# Altium schematic sheet Interfaces.SchDoc(Interfaces)
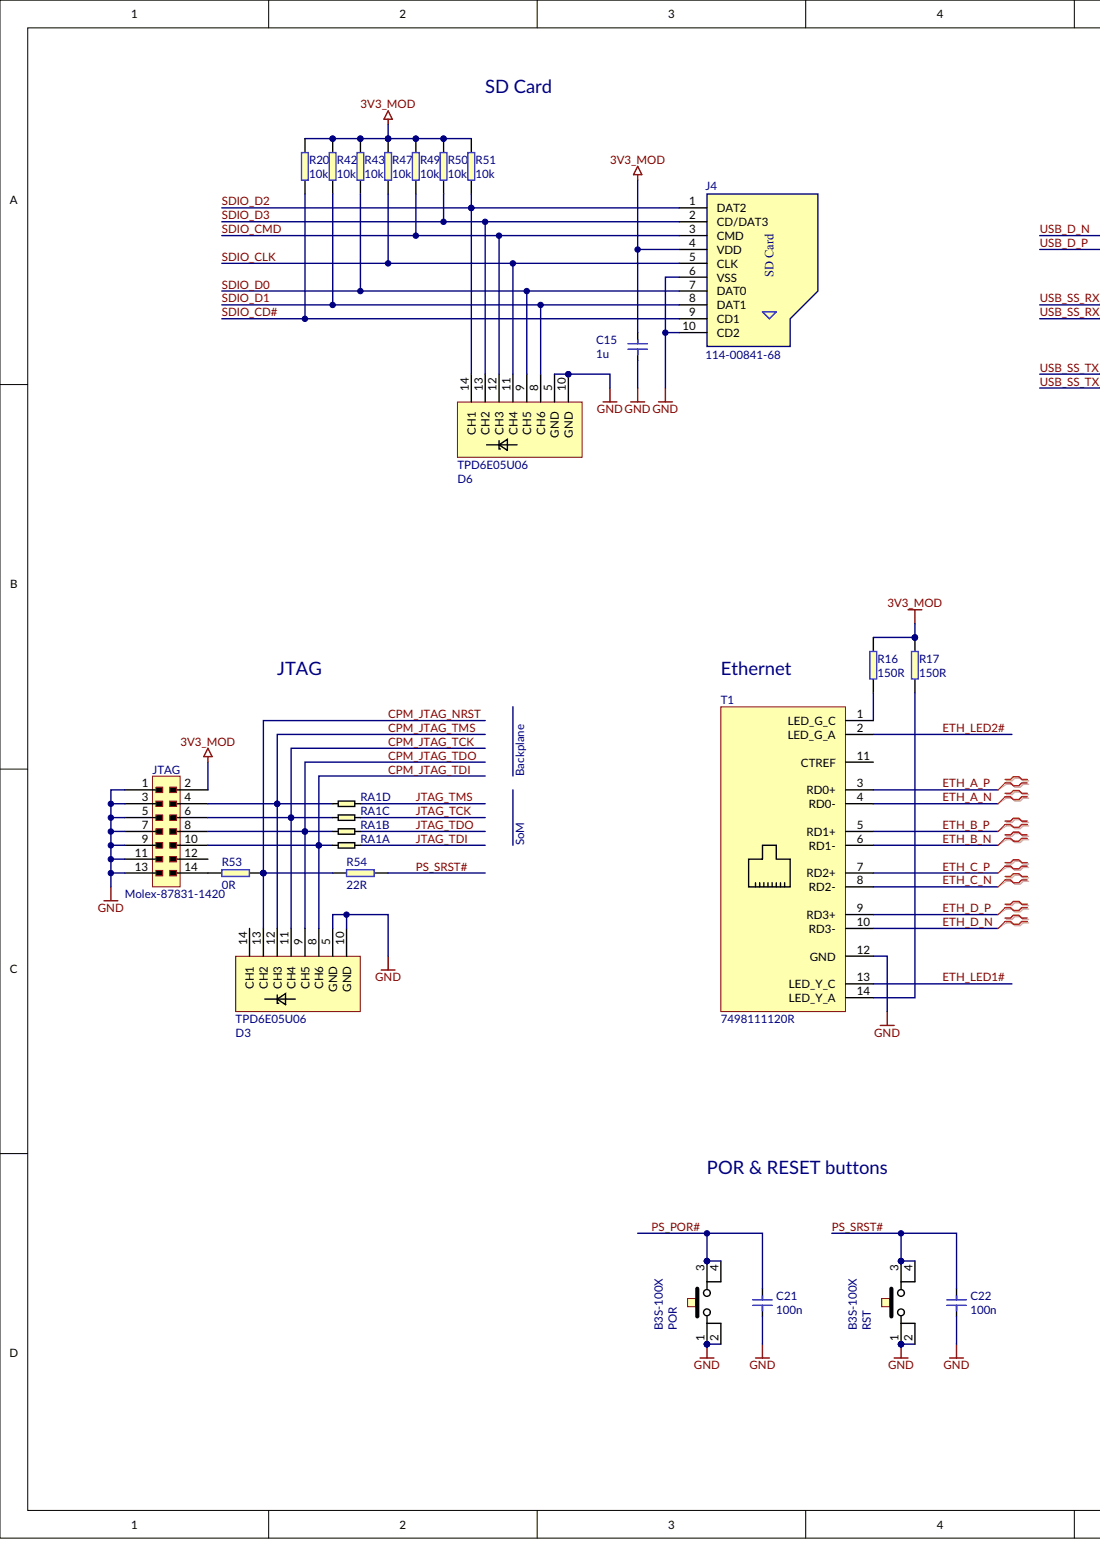
[diagram p0: Interfaces.SchDoc, left]
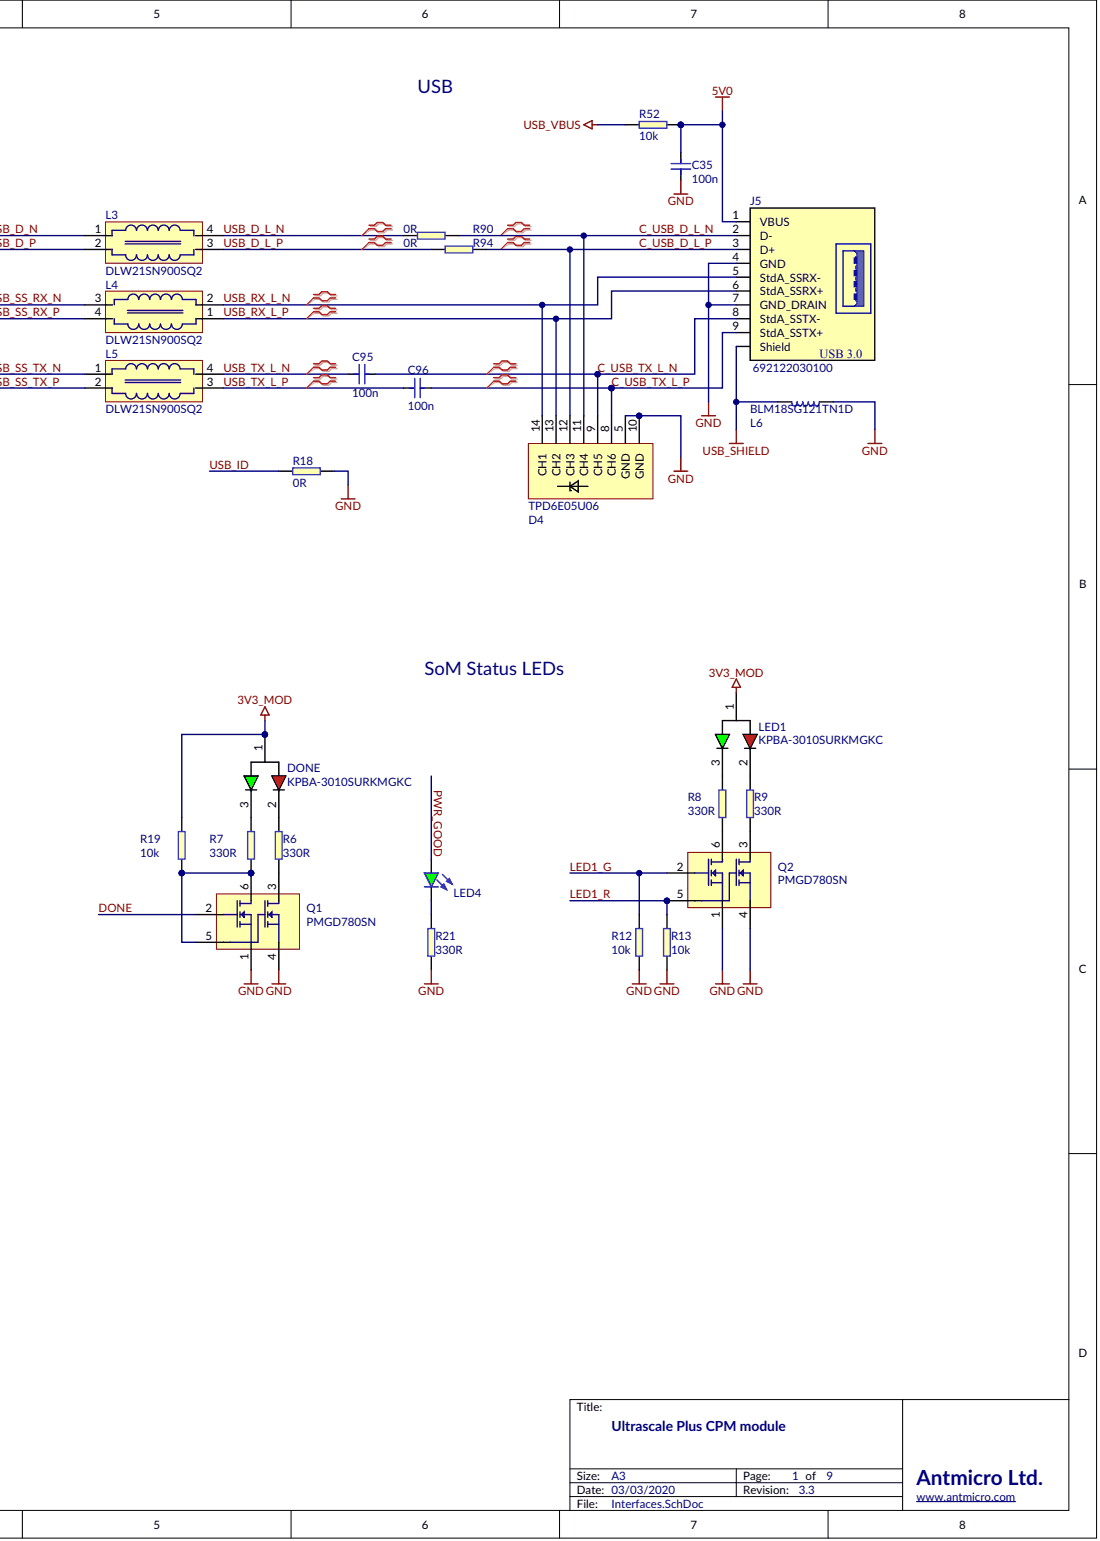
[diagram p1: Interfaces.SchDoc, right]

## Parts
C15  1u
C21  100n
C22  100n
C35  100n
C95  100n
C96  100n
D3  TPD6E05U06
D4  TPD6E05U06
D6  TPD6E05U06
DONE  KPBA-3010SURKMGKC
J4  114-00841-68
J5  692122030100
JTAG  Molex-87831-1420
L3  DLW21SN900SQ2
L4  DLW21SN900SQ2
L5  DLW21SN900SQ2
L6  BLM18SG121TN1D
LED1  KPBA-3010SURKMGKC
LED4  KPT-1608SGC  (value not drawn)
POR  B3S-100X
Q1  PMGD780SN
Q2  PMGD780SN
R6  330R
R7  330R
R8  330R
R9  330R
R12  10k
R13  10k
R16  150R
R17  150R
R18  0R
R19  10k
R20  10k
R21  330R
R42  10k
R43  10k
R47  10k
R49  10k
R50  10k
R51  10k
R52  10k
R53  0R
R54  22R
R90  0R
R94  0R
RA1  22R  (value not drawn)  (parts A,B,C,D)
RST  B3S-100X
T1  7498111120R

## Nets
3V3_MOD: C15.2 DONE.1 J4.4(VDD) JTAG.2 LED1.1 R16.2 R17.2 R19.2 R20.2 R42.2 R43.2 R47.2 R49.2 R50.2 R51.2
5V0: C35.2 J5.1(VBUS) R52.2
GND: C15.1 C21.1 C22.1 C35.1 D3.5(GND) D3.10(GND) D4.5(GND) D4.10(GND) D6.5(GND) D6.10(GND) J4.6(VSS) J4.10(CD2) J5.4(GND) J5.7(GND_DRAIN) JTAG.1 JTAG.3 JTAG.5 JTAG.7 JTAG.9 JTAG.11 JTAG.13 L6.2 POR.1 POR.2 Q1.1 Q1.4 Q2.1 Q2.4 R12.1 R13.1 R18.2 R21.2 RST.1 RST.2 T1.12(GND)
USB_SHIELD: J5.Z1(Shield) L6.1
USB_VBUS: R52.1
C_USB_D_L_N: D4.11(CH4) J5.2(D-) R90.2
C_USB_D_L_P: D4.12(CH3) J5.3(D+) R94.2
C_USB_TX_L_N: C95.2 D4.9(CH5) J5.8(StdA_SSTX-)
C_USB_TX_L_P: C96.2 D4.8(CH6) J5.9(StdA_SSTX+)
CPM_JTAG_NRST: D3.13(CH2) R53.2 R54.1
CPM_JTAG_TCK: D3.11(CH4) JTAG.6 RA1.3
CPM_JTAG_TDI: D3.8(CH6) JTAG.10 RA1.1
CPM_JTAG_TDO: D3.9(CH5) JTAG.8 RA1.2
CPM_JTAG_TMS: D3.12(CH3) JTAG.4 RA1.4
DONE: Q1.2
ETH_A_N: T1.4(RD0-)
ETH_A_P: T1.3(RD0+)
ETH_B_N: T1.6(RD1-)
ETH_B_P: T1.5(RD1+)
ETH_C_N: T1.8(RD2-)
ETH_C_P: T1.7(RD2+)
ETH_D_N: T1.10(RD3-)
ETH_D_P: T1.9(RD3+)
ETH_LED1#: T1.13(LED_Y_C)
ETH_LED2#: T1.2(LED_G_A)
JTAG_TCK: RA1.7
JTAG_TDI: RA1.5
JTAG_TDO: RA1.6
JTAG_TMS: RA1.8
LED1_G: Q2.2 R12.2
LED1_R: Q2.5 R13.2
PS_POR#: C21.2 POR.3 POR.4
PS_SRST#: C22.2 R54.2 RST.3 RST.4
PWR_GOOD: LED4.A
SDIO_CD#: J4.9(CD1) R20.1
SDIO_CLK: D6.11(CH4) J4.5(CLK) R47.1
SDIO_CMD: D6.12(CH3) J4.3(CMD) R49.1
SDIO_D0: D6.9(CH5) J4.7(DAT0) R43.1
SDIO_D1: D6.8(CH6) J4.8(DAT1) R42.1
SDIO_D2: D6.14(CH1) J4.1(DAT2) R51.1
SDIO_D3: D6.13(CH2) J4.2(CD/DAT3) R50.1
USB_D_L_N: L3.4 R90.1
USB_D_L_P: L3.3 R94.1
USB_D_N: L3.1
USB_D_P: L3.2
USB_ID: R18.1
USB_RX_L_N: D4.14(CH1) J5.5(StdA_SSRX-) L4.2
USB_RX_L_P: D4.13(CH2) J5.6(StdA_SSRX+) L4.1
USB_SS_RX_N: L4.3
USB_SS_RX_P: L4.4
USB_SS_TX_N: L5.1
USB_SS_TX_P: L5.2
USB_TX_L_N: C95.1 L5.4
USB_TX_L_P: C96.1 L5.3
NetDONE_2: DONE.2 R6.1
NetDONE_3: DONE.3 R7.1
NetJTAG_14: JTAG.14 R53.1
NetLED1_2: LED1.2 R9.2
NetLED1_3: LED1.3 R8.2
NetLED4_K: LED4.K R21.1
NetQ1_3: Q1.3 R6.2
NetQ1_5: Q1.5 Q1.6 R7.2 R19.1
NetQ2_3: Q2.3 R9.1
NetQ2_6: Q2.6 R8.1
NetR16_1: R16.1 T1.1(LED_G_C)
NetR17_1: R17.1 T1.14(LED_Y_A)

Not connected: D3 14(CH1); JTAG 12; T1 11(CTREF)

## Library data of the parts
C15 = (no symbol name): Part Number: C_1u_0603; Manufacturer: MULTICOMP; Part Symbol: MC0603X105M6R3CT; Value: 1u; Supplier 1: Farnell; Supplier Part Number 1: 2309013
C21 C22 = (no symbol name): Part Number: C_100n_0603; Manufacturer: WALSIN; Part Symbol: 0603B104K500CT; Value: 100n; Supplier 1: Farnell; Supplier Part Number 1: 2496834
C35 C95 C96 = (no symbol name): Part Number: C_100n_0402; Manufacturer: MULTICOMP; Part Symbol: MC0402X104M100CT; Value: 100n; Supplier 1: Farnell; Supplier Part Number 1: 2309011
D3 D4 D6 = (no symbol name): Part Number: TPD6E05U06_PASS; Manufacturer: Texas Instruments; Part Symbol: TPD6E05U06RVZR; Value: TPD6E05U06; Supplier 1: Digi-Key; Supplier Part Number 1: 296-39349-1-ND
DONE LED1 = (no symbol name): Manufacturer: Kingbright; Part Number: KPBA-3010SURKMGKC; Value: KPBA-3010SURKMGKC; Supplier 1: Farnell; Supplier Part Number 1: 2464016; Part Symbol: KPBA-3010SURKMGKC
J4 = (no symbol name): Part Number: AMP-114-00841-68; Manufacturer: AMPHENOL COMMERCIAL PRODUCTS; Supplier Part Number 1: 2293708; Value: 114-00841-68; Supplier 1: Farnell; Part Symbol: 114-00841-68
J5 = (no symbol name): Part Number: WE_692122030100; Manufacturer: WURTH ELEKTRONIK; Supplier Part Number 1: 1861255; Value: 692122030100; Supplier 1: Farnell; Part Symbol: 692122030100
JTAG = (no symbol name): Part Number: CON-Molex-87831-1420; Manufacturer: MOLEX; Supplier Part Number 1: 7472285; Supplier 2: Mouser; Supplier Part Number 2: 538-87831-1420; Value: Molex-87831-1420; Supplier 1: Farnell; Part Symbol: 87831-1420
L3-L5 = (no symbol name): Manufacturer: MURATA; Part Symbol: DLW21SN900SQ2L; Part Number: DLW21SN900SQ2; Value: DLW21SN900SQ2; Supplier 1: Farnell; Supplier Part Number 1: 1515594
L6 = (no symbol name): Manufacturer: MURATA; Rated Current: 3A; Part Number: BLM18SG121TN1D; Value: BLM18SG121TN1D; Supplier 1: Farnell; Supplier Part Number 1: 1515752; Part Symbol: BLM18SG121TN1D
LED4 = (no symbol name): Part Number: LED_G; Manufacturer: KINGBRIGHT; Value: KPT-1608SGC; Supplier 1: Farnell; Supplier Part Number 1: 2099223; Part Symbol: KPT-1608SGC
POR RST = (no symbol name): Manufacturer: OMRON; Part Symbol: B3S-1002; Part Number: B3S-100X; Value: B3S-100X; Supplier 1: Farnell; Supplier Part Number 1: 959728; Supplier 2: TME; Supplier Part Number 2: B3S-1002P
Q1 Q2 = (no symbol name): Manufacturer: NXP; Part Symbol: PMGD780SN,115; Part Number: PMGD780SN; Value: PMGD780SN; Supplier 1: Farnell; Supplier Part Number 1: 1758102
R6 R7 R21 = (no symbol name): Part Number: R_330R_0603; Manufacturer: BOURNS; Part Symbol: CR0603-FX-3300GLF; Tolerance: 5%; Value: 330R; Component type: standard; Pin count: 2; Supplier 1: Farnell; Supplier Part Number 1: 2008334
R8 R9 = (no symbol name): Part Number: R_330R_0402; Manufacturer: MULTICOMP; Part Symbol: MCMR04X3300FTL; Tolerance: 1%; Value: 330R; Supplier 1: Farnell; Supplier Part Number 1: 2072927
R12 R13 R20 R42 R43 R47 R49-R51 = (no symbol name): Part Number: R_10k_0402; Manufacturer: MULTICOMP; Part Symbol: MCMR04X1002FTL; Tolerance: 1%; Value: 10k; Supplier 1: Farnell; Supplier Part Number 1: 2072517
R16 R17 = (no symbol name): Part Number: R_150R_0603; Manufacturer: MULTICOMP; Part Symbol: MC0063W06031150R; Value: 150R; Supplier 1: Farnell; Supplier Part Number 1: 9330593
R18 R53 = (no symbol name): Part Number: R_0R_0603; Manufacturer: BOURNS; Part Symbol: CR0603-J/-000ELF; Tolerance: 5%; Value: 0R; Supplier 1: Farnell; Supplier Part Number 1: 2008343
R19 R52 = (no symbol name): Part Number: R_10k_0603; Manufacturer: BOURNS; Part Symbol: CR0603-JW-103ELF; Value: 10k; Supplier 1: Farnell; Supplier Part Number 1: 2008360
R54 = (no symbol name): Part Number: R_22R_0603; Manufacturer: BOURNS; Part Symbol: CR0603-JW-220GLF; Value: 22R; Supplier 1: Farnell; Supplier Part Number 1: 2008345
R90 R94 = (no symbol name): Part Number: R_0R_0402; Manufacturer: MULTICOMP; Part Symbol: MCMR04X000 PTL; Value: 0R; Supplier 1: Farnell; Supplier Part Number 1: 2072513
RA1 = (no symbol name): Part Number: RA-22R-63mW-5%-1206; Manufacturer: YAGEO (PHYCOMP); Part Symbol: TC164-JR-0722RL; Value: 22R; Supplier 1: Farnell; Supplier Part Number 1: 9241159
T1 = (no symbol name): Part Number: WE_7498111120R; Manufacturer: WURTH ELEKTRONIK; Supplier Part Number 1: 2356101; Value: 7498111120R; Supplier 1: Farnell; Part Symbol: 7498111120R
